# Altium schematic sheet smartport_inverter.SchDoc
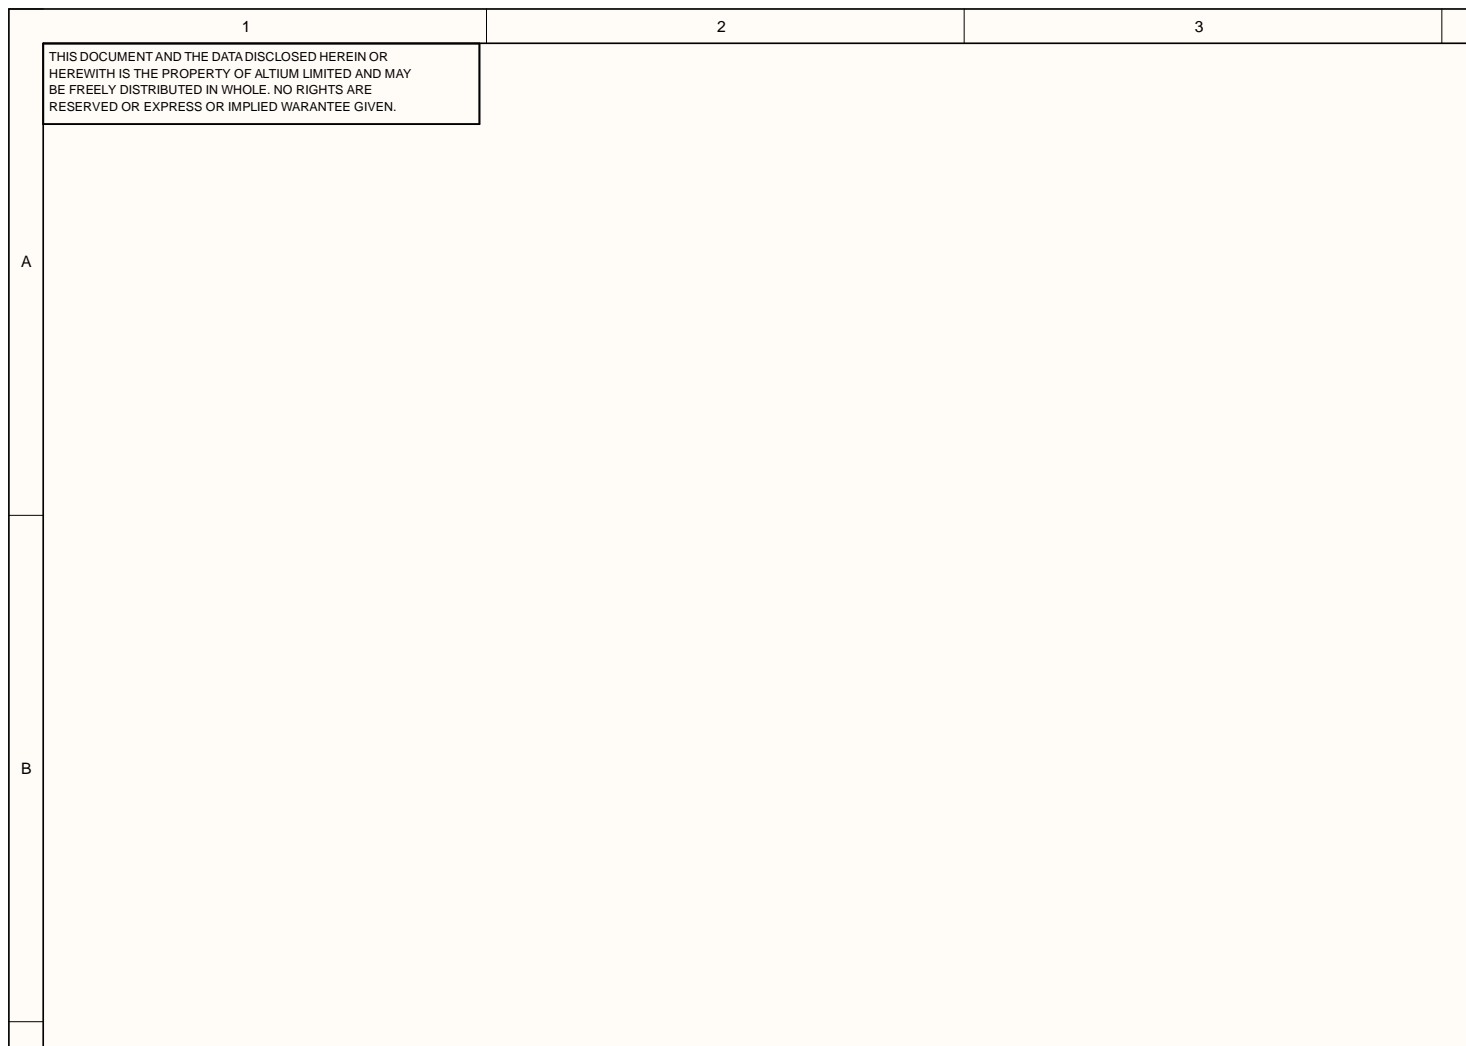
[diagram p0: smartport_inverter.SchDoc, top left]
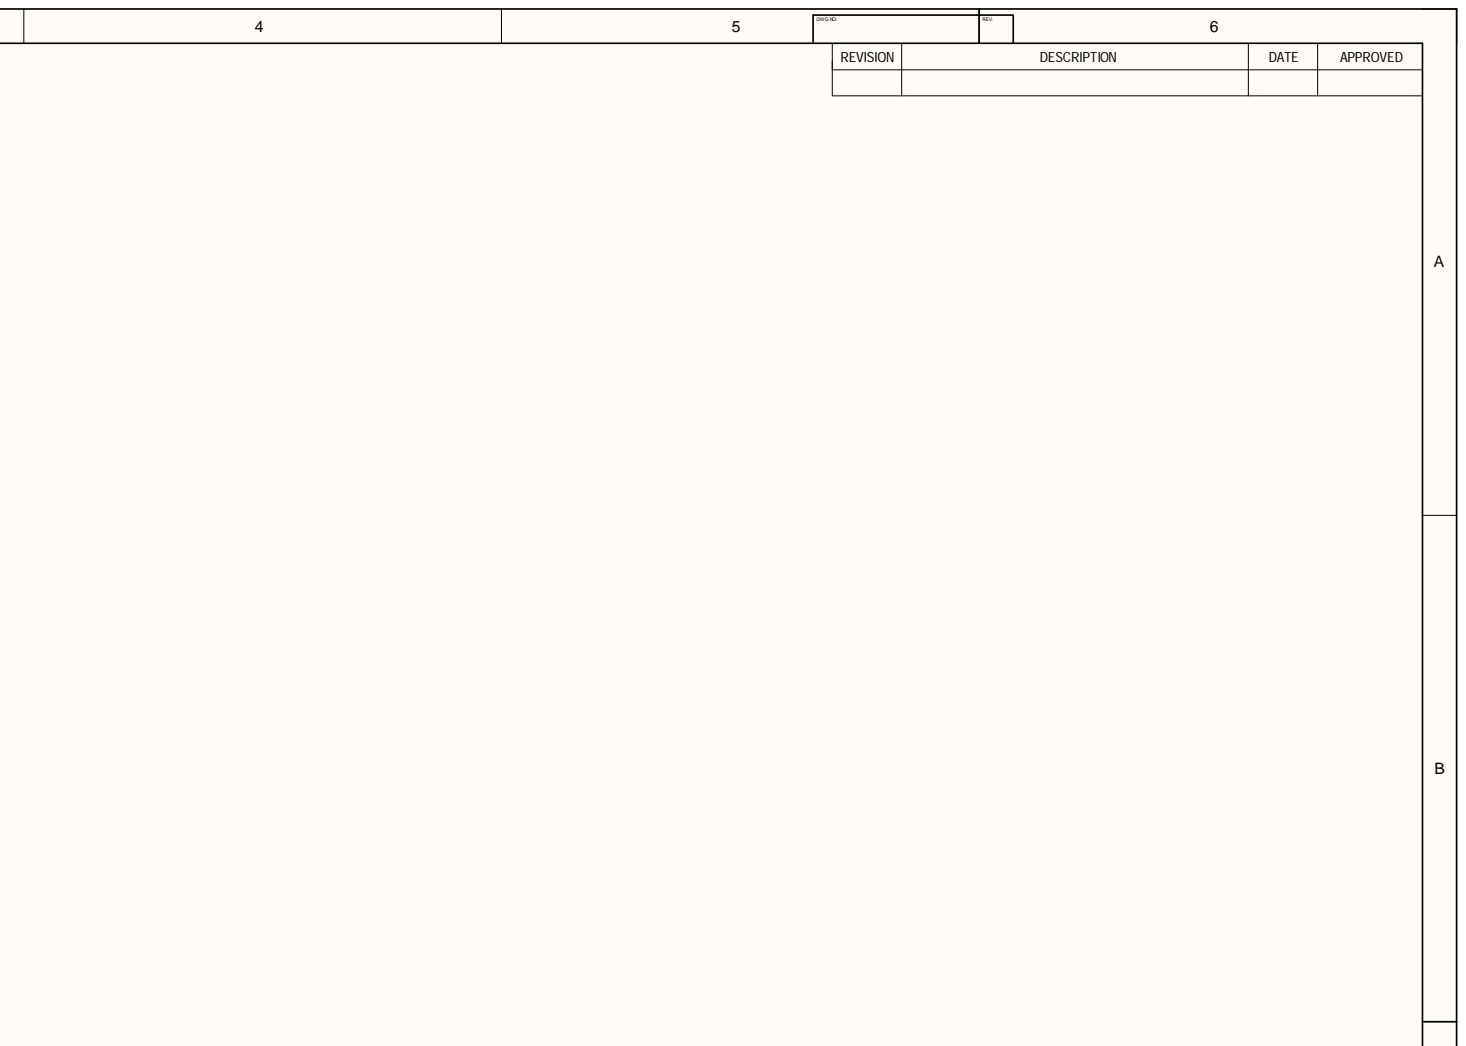
[diagram p1: smartport_inverter.SchDoc, top right]
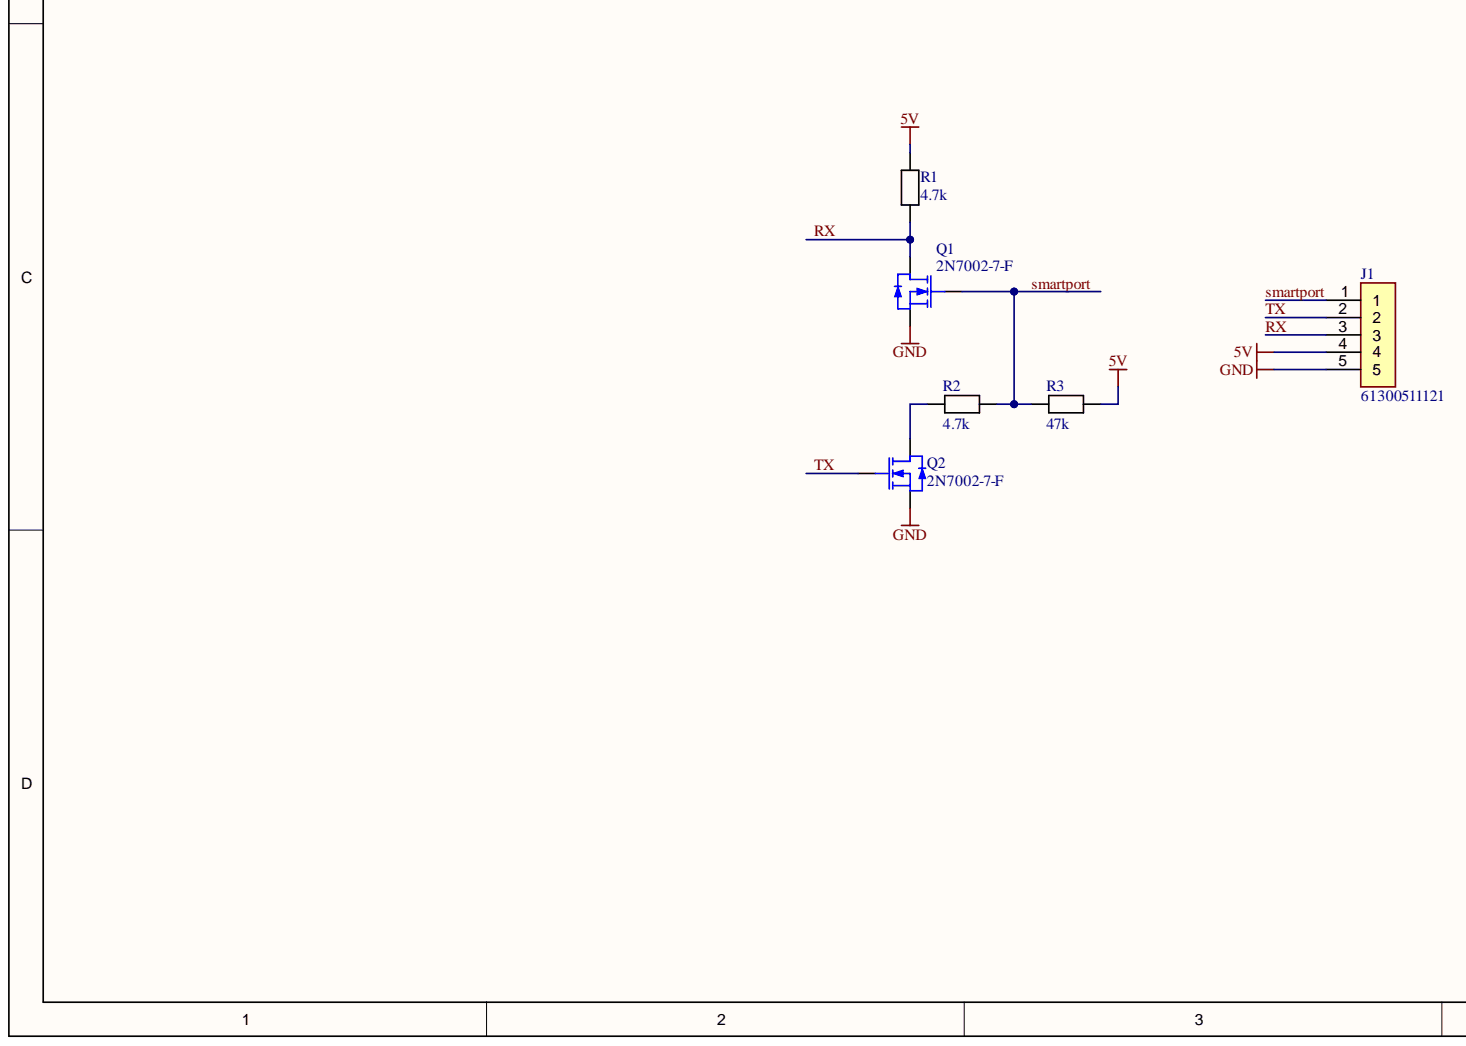
[diagram p2: smartport_inverter.SchDoc, bottom left]
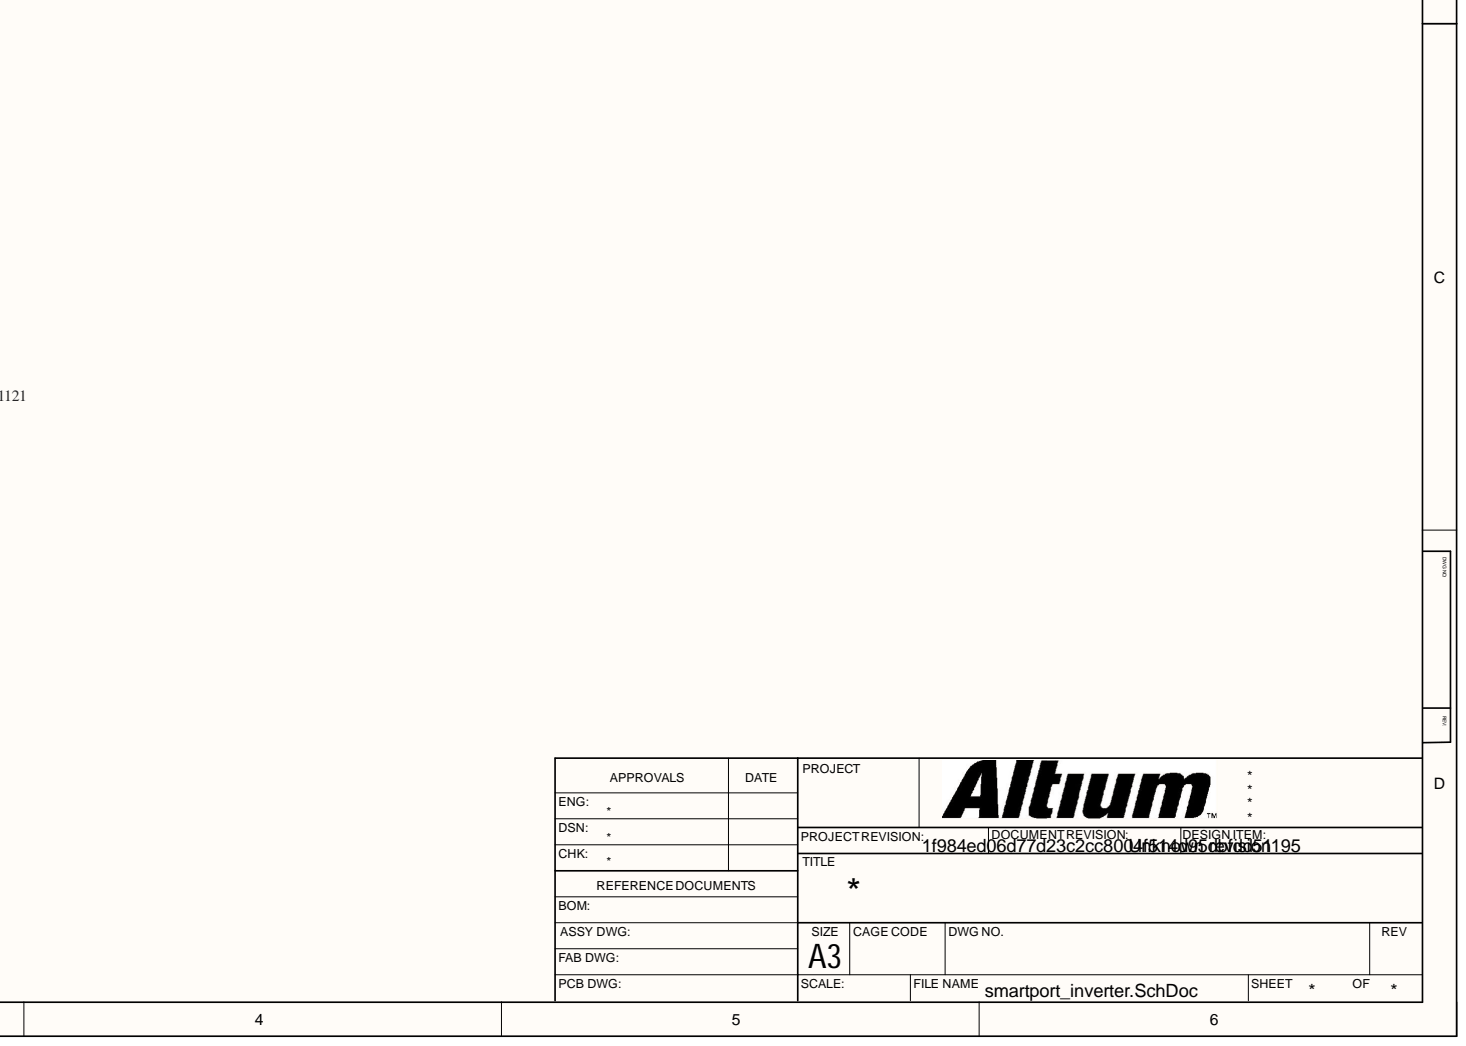
[diagram p3: smartport_inverter.SchDoc, bottom right]

## Parts
J1  61300511121  [61300511121]
Q1  2N7002-7-F  [SOT23-3N]
Q2  2N7002-7-F  [SOT23-3N]
R1  4.7k  [R0603]
R2  4.7k  [R0603]
R3  47k  [R0603]

## Nets
5V: J1.4 R1.2 R3.1
GND: J1.5 Q1.2 Q2.2
RX: J1.3 Q1.3 R1.1
smartport: J1.1 Q1.1 R2.1 R3.2
TX: J1.2 Q2.1
NetQ2_3: Q2.3 R2.2

## Library data of the parts
J1 = 61300511121: Headers & Wire Housings WR-PHD 2.54mm Hdr 5P Single Str Gold; Manufacturer: Wurth Elektronik; Component Kind: Standard; Manufacturer Part Number: 61300511121; Value: 61300511121; Library Name: EnergyMeter.SchLib
Q1 Q2 = 2N7002-7-F: MOSFET N-CH 60V 200MA SOT23-3; Manufacturer: Diodes Incorporated; Component Kind: Standard; Manufacturer Part Number: 2N7002-7-F; Value: 2N7002-7-F; Library Name: EnergyMeter.SchLib
R1 R2 = RES_YAG_RC0603FR-074K7L: Resistor 4.7k 0603 +-1% 0.1; Manufacturer: Yageo; Power (Watts): 0.1; Temperature Coefficient: +-200ppm°C; Voltage (V): 75; Category: Resistor; Component Kind: Standard; Library Name: Res_Yag_0603_1%$.SchLib; Manufacturer Part Number: RC0603FR-074K7L; Operating Temperature: -55°C to +155°C; Package / Case: 0603; Part Number: RES_YAG_RC0603FR-074K7L; Resistor (Ohms): 4.7k; Thickness (mm): 0.45; Tolerance: +-1%; Value: 4.7k
R3 = RES_YAG_RC0603FR-0747KL: Resistor 47k 0603 +-1% 0.1; Manufacturer: Yageo; Power (Watts): 0.1; Temperature Coefficient: +-200ppm°C; Voltage (V): 75; Category: Resistor; Component Kind: Standard; Library Name: Res_Yag_0603_1%$.SchLib; Manufacturer Part Number: RC0603FR-0747KL; Operating Temperature: -55°C to +155°C; Package / Case: 0603; Part Number: RES_YAG_RC0603FR-0747KL; Resistor (Ohms): 47k; Thickness (mm): 0.45; Tolerance: +-1%; Value: 47k
